# Altium schematic sheet WifiDB1.SchDoc
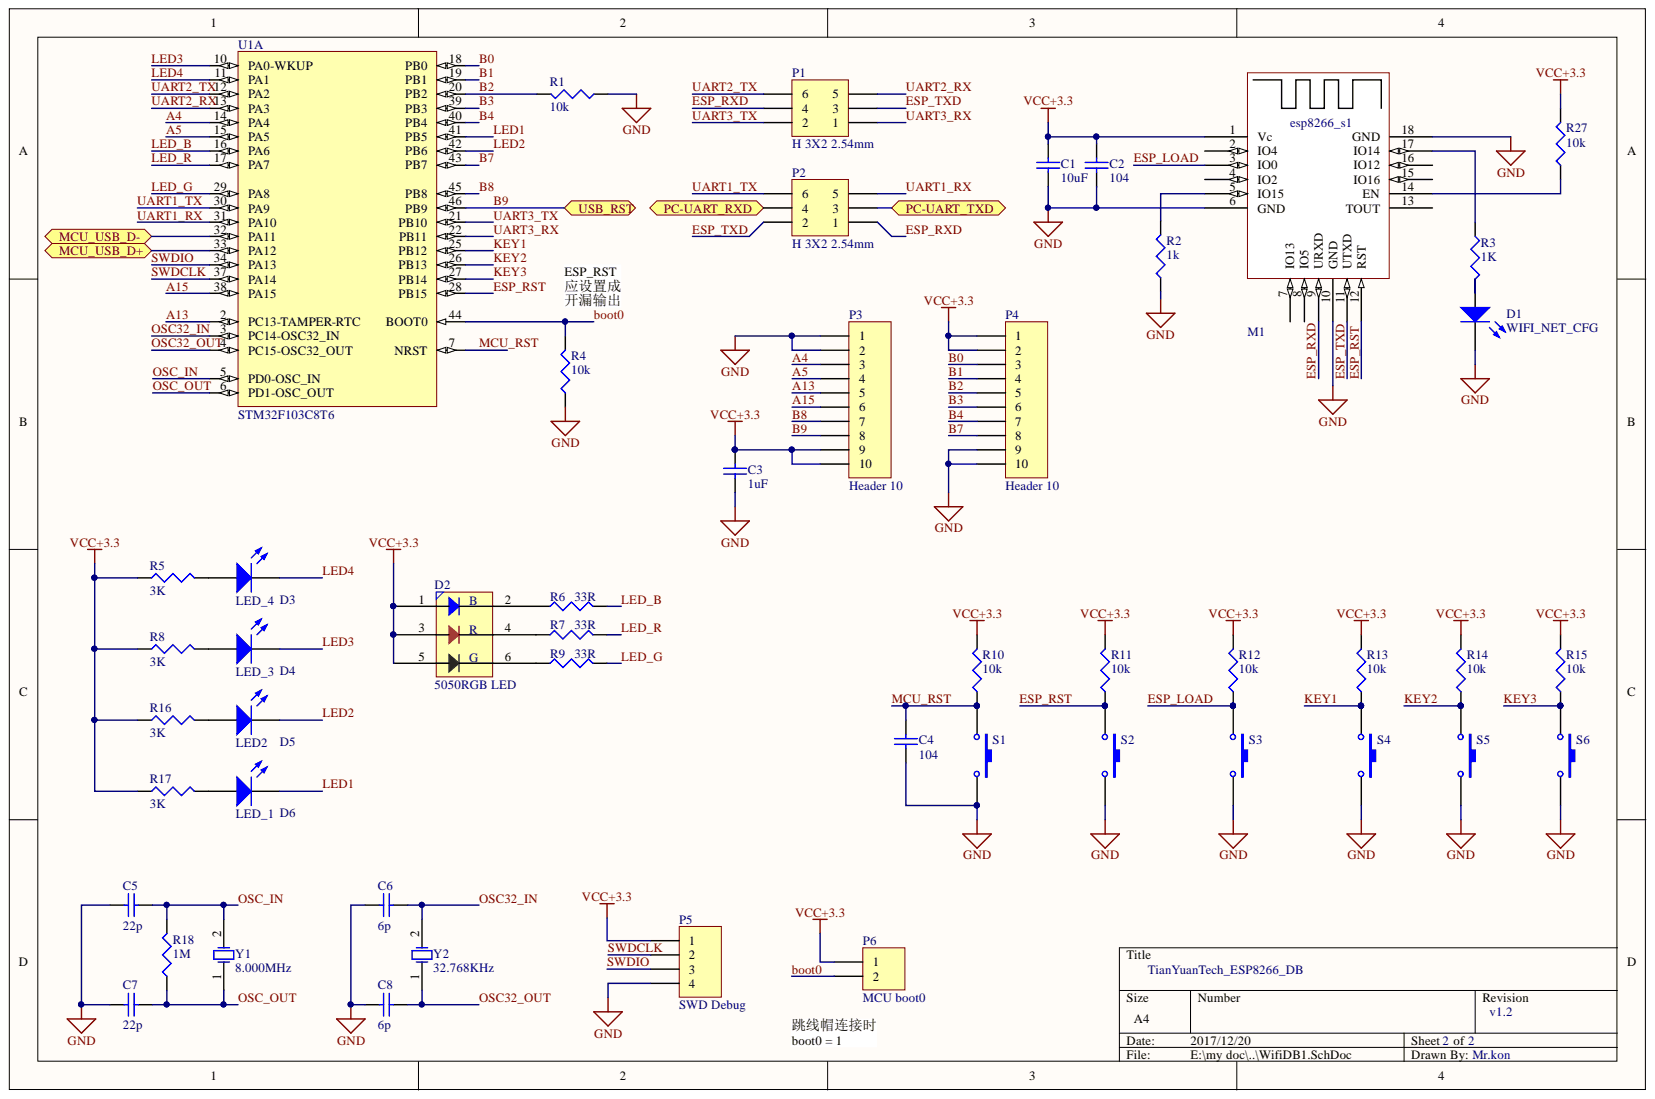
[diagram p0: WifiDB1.SchDoc]

## Parts
C1  10uF  [1608[0603]]
C2  104  [1608[0603]]
C3  1uF  [1608[0603]]
C4  104  [1608[0603]]
C5  22p  [1608[0603]]
C6  6p  [1608[0603]]
C7  22p  [1608[0603]]
C8  6p  [1608[0603]]
D1  WIFI_NET_CFG  [LED0805]
D2  5050RGB LED  [LED RGB5050]
D3  LED_4  [LED0805]
D4  LED_3  [LED0805]
D5  LED2  [LED0805]
D6  LED_1  [LED0805]
M1  esp8266-s1  [ESP8266_S1]
P1  H 3X2 2.54mm  [HDR2X3]
P2  H 3X2 2.54mm  [HDR2X3]
P3  Header 10  [HDR1X10]
P4  Header 10  [HDR1X10]
P5  SWD Debug  [HDR1X4]
P6  MCU boot0  [HDR1X2]
R1  value 10k  [J1-0603]  (hidden comment: 0603)
R2  value 1k  [J1-0603]  (hidden comment: 0603)
R3  value 1K  [J1-0603]  (hidden comment: Res3)
R4  value 10k  [J1-0603]  (hidden comment: 0603)
R5  value 3K  [J1-0603]  (hidden comment: Res3)
R6  value 33R  [J1-0603]  (hidden comment: Res3)
R7  value 33R  [J1-0603]  (hidden comment: Res3)
R8  value 3K  [J1-0603]  (hidden comment: Res3)
R9  value 33R  [J1-0603]  (hidden comment: Res3)
R10  value 10k  [J1-0603]  (hidden comment: 0603)
R11  value 10k  [J1-0603]  (hidden comment: 0603)
R12  value 10k  [J1-0603]  (hidden comment: 0603)
R13  value 10k  [J1-0603]  (hidden comment: 0603)
R14  value 10k  [J1-0603]  (hidden comment: 0603)
R15  value 10k  [J1-0603]  (hidden comment: 0603)
R16  value 3K  [J1-0603]  (hidden comment: Res3)
R17  value 3K  [J1-0603]  (hidden comment: Res3)
R18  value 1M  [J1-0603]  (hidden comment: 0603)
R27  value 10k  [J1-0603]  (hidden comment: 0603)
S1  SW-5mm_6x6_SMT  [SW-SMT-6*6*N]  (value not drawn)
S2  SW-5mm_6x6_SMT  [SW-SMT-6*6*N]  (value not drawn)
S3  SW-5mm_6x6_SMT  [SW-SMT-6*6*N]  (value not drawn)
S4  SW-5mm_6x6_SMT  [SW-SMT-6*6*N]  (value not drawn)
S5  SW-5mm_6x6_SMT  [SW-SMT-6*6*N]  (value not drawn)
S6  SW-5mm_6x6_SMT  [SW-SMT-6*6*N]  (value not drawn)
U1  STM32F103C8T6  [STM-LQFP48_N]  (part A)
Y1  8.000MHz  [XTAL]
Y2  32.768KHz  [R38]

## Ports
MCU_USB_D+, MCU_USB_D-, PC-UART_RXD, PC-UART_TXD, USB_RST

## Nets
GND: C1.1 C2.1 C3.2 C4.2 C5.2 C6.2 C7.2 C8.2 D1.2 M1.6(GND) M1.10(GND) M1.18(GND) P3.1 P3.2 P4.9 P4.10 P5.4 R1.1 R2.1 R4.1 S1.2 S2.2 S3.2 S4.2 S5.2 S6.2
VCC+3.3: C1.2 C2.2 C3.1 D2.1 D2.3 D2.5 M1.1(Vc) P3.9 P3.10 P4.1 P4.2 P5.1 P6.1 R5.1 R8.1 R10.2 R11.2 R12.2 R13.2 R14.2 R15.2 R16.1 R17.1 R27.2
A4: P3.3 U1.14(PA4)
A5: P3.4 U1.15(PA5)
A13: P3.5 U1.2(PC13-TAMPER-RTC)
A15: P3.6 U1.38(PA15)
B0: P4.3 U1.18(PB0)
B1: P4.4 U1.19(PB1)
B2: P4.5 R1.2 U1.20(PB2)
B3: P4.6 U1.39(PB3)
B4: P4.7 U1.40(PB4)
B7: P4.8 U1.43(PB7)
B8: P3.7 U1.45(PB8)
B9: P3.8 U1.46(PB9) ; port USB_RST
BOOT0: P6.2 R4.2 U1.44(BOOT0)
ESP_LOAD: M1.3(IO0) R12.1 S3.1
ESP_RST: M1.12(RST) R11.1 S2.1 U1.28(PB15)
ESP_RXD: M1.9(URXD) P1.4 P2.1
ESP_TXD: M1.11(UTXD) P1.3 P2.2
KEY1: R13.1 S4.1 U1.25(PB12)
KEY2: R14.1 S5.1 U1.26(PB13)
KEY3: R15.1 S6.1 U1.27(PB14)
LED1: D6.2 U1.41(PB5)
LED2: D5.2 U1.42(PB6)
LED3: D4.2 U1.10(PA0-WKUP)
LED4: D3.2 U1.11(PA1)
LED_B: R6.2 U1.16(PA6)
LED_G: R9.2 U1.29(PA8)
LED_R: R7.2 U1.17(PA7)
MCU_RST: C4.1 R10.1 S1.1 U1.7(NRST)
OSC32_IN: C6.1 U1.3(PC14-OSC32_IN) Y2.2
OSC32_OUT: C8.1 U1.4(PC15-OSC32_OUT) Y2.1
OSC_IN: C5.1 R18.2 U1.5(PD0-OSC_IN) Y1.2
OSC_OUT: C7.1 R18.1 U1.6(PD1-OSC_OUT) Y1.1
PC-UART_RXD: P2.4 ; port PC-UART_RXD
PC-UART_TXD: P2.3 ; port PC-UART_TXD
SWDCLK: P5.2 U1.37(PA14)
SWDIO: P5.3 U1.34(PA13)
UART1_RX: P2.5 U1.31(PA10)
UART1_TX: P2.6 U1.30(PA9)
UART2_RX: P1.5 U1.13(PA3)
UART2_TX: P1.6 U1.12(PA2)
UART3_RX: P1.1 U1.22(PB11)
UART3_TX: P1.2 U1.21(PB10)
NetD1_1: D1.1 R3.2
NetD2_2: D2.2(B) R6.1
NetD2_4: D2.4(R) R7.1
NetD2_6: D2.6(G) R9.1
NetD3_1: D3.1 R5.2
NetD4_1: D4.1 R8.2
NetD5_1: D5.1 R16.2
NetD6_1: D6.1 R17.2
NetM1_5: M1.5(IO15) R2.2
NetM1_14: M1.14(EN) R27.1
NetM1_17: M1.17(IO14) R3.1
NetU1_32: U1.32(PA11)
NetU1_33: U1.33(PA12)

Not connected: M1 2(IO4) 4(IO2) 7(IO13) 8(IO5) 13(TOUT) 15(IO16) 16(IO12)

## Library data of the parts
C1-C8 = C 0603/25v: Component Kind: Standard; Library Name: mysch.SchLib
D1 D3-D6 = LED2: Typical RED, GREEN, YELLOW, AMBER GaAs LED; Component Kind: Standard; LatestRevisionDate: 17-Jul-2002; LatestRevisionNote: Re-released for DXP Platform.; Library Name: Miscellaneous Devices.IntLib; PackageDescription: SM LED; 2 Flat Leads; PackageReference: 3.2X1.6X1.1; PackageVersion: Feb-2002; Published: 8-Jun-2000; Simulation: LED2; Publisher: Altium Limited
D2 = BRG_LED: Component Kind: Standard; Library Name: New_Integrated_Library.IntLib
M1 = SEP8266_S1: Component Kind: Standard; Library Name: New_Integrated_Library.IntLib
P1 P2 = Header 3X2: Header, 3-Pin, Dual row; Component Kind: Standard; LatestRevisionDate: 17-Jul-2002; LatestRevisionNote: Re-released for DXP Platform.; Library Name: Miscellaneous Connectors.IntLib; Signal Integrity: Connector; Publisher: Altium Limited
P3 P4 = Header 10: Header, 10-Pin; Component Kind: Standard; LatestRevisionDate: 17-Jul-2002; LatestRevisionNote: Re-released for DXP Platform.; Library Name: Miscellaneous Connectors.IntLib; Signal Integrity: Connector; Publisher: Altium Limited
P5 = Header 4: Header, 4-Pin 2.54; Component Kind: Standard; LatestRevisionDate: 17-Jul-2002; LatestRevisionNote: Re-released for DXP Platform.; Library Name: Miscellaneous Connectors.IntLib; Signal Integrity: Connector; Publisher: Altium Limited
P6 = Header 2: Header, 2-Pin; Component Kind: Standard; LatestRevisionDate: 17-Jul-2002; LatestRevisionNote: Re-released for DXP Platform.; Library Name: Miscellaneous Connectors.IntLib; Signal Integrity: Connector; Publisher: Altium Limited
R1-R18 R27 = Res3: Resistor; Component Kind: Standard; LatestRevisionDate: 29-May-2009; LatestRevisionNote: IPC-7351 Footprint Added.; Library Name: Miscellaneous Devices.IntLib; PackageDescription: Chip Resistor; PackageReference: J1-0603; Published: 8-Jun-2000; Signal Integrity: Res; Simulation: RESISTOR; Publisher: Altium Limited
S1-S6 = SW-PB: Switch; Component Kind: Standard; LatestRevisionDate: 17-Jul-2002; LatestRevisionNote: Re-released for DXP Platform.; Library Name: Miscellaneous Devices.IntLib; PackageDescription: Switch; 2 Leads; PackageReference: SPST-2; Published: 24-Mar-1999; Publisher: Altium Limited
U1 = STM32F103C8T6: ARM Cortex-M3 32-bit MCU, 64 KB Flash, 20 KB Internal RAM, 37 I/Os, 48-pin LQFP, -40 to 85 degC, Tray; A/D Converter: 2x12-bit (10 Channel); FLASH Size (Prog) (kB): 64; I/Os (High Current): 37; Internal RAM Size (kB): 20; Operating Frequency(F) (Processor speed) (MHz): 72; Supply Voltage(Vcc) min (V): 2; Component Kind: Standard; ComponentLink1Description: Manufacturer URL; ComponentLink1URL: http://www.st.com/web/en/home.html; ComponentLink2Description: Datasheet; ComponentLink2URL: http://www.st.com/st-web-ui/static/active/en/resource/technical/document/datasheet/CD00161566.pdf; ComponentLink3Description: Package Specification; ComponentLink3URL: http://www.st.com/st-web-ui/static/active/en/resource/technical/document/datasheet/DM00058181.pdf; Core: ARM Cortex-M3; DatasheetVersion: Rev. 16; Library Name: STMicroelectronics STM32 F1.IntLib; Mounting Technology: Surface Mount; PackageDescription: 48-Pin Low Profile Quad Flat Package, 7 x 7 mm Body, 0.5 mm Pitch; PackageReference: LQFP48; PackageVersion: Rev. 6, 06/2013; Packing: Tray; PartNumber: STM32F103C8T6; Supplier 1: Digi-Key; Supplier 2: Farnell; Supplier 3: Newark; Supplier 4: Newark; Supplier 5: Mouser; Supplier Part Number 1: 497-6063-ND; Supplier Part Number 2: 1447637; Supplier Part Number 3: 59M2182; Supplier Part Number 4: 57P1222; Supplier Part Number 5: 511-STM32F103C8T6
Y1 Y2 = XTAL: Crystal Oscillator; Component Kind: Standard; LatestRevisionDate: 17-Jul-2002; LatestRevisionNote: Re-released for DXP Platform.; Library Name: Miscellaneous Devices.IntLib; PackageDescription: Crystal, Thru-Hole; 2 Leads; Body 3.1 x 8.2 mm (Dia.xH); PackageReference: R38; Published: 8-Jun-2000; Simulation: XTAL; Publisher: Altium Limited
